# Altium schematic sheet 08_DDR_64.SchDoc(08_DDR_64)
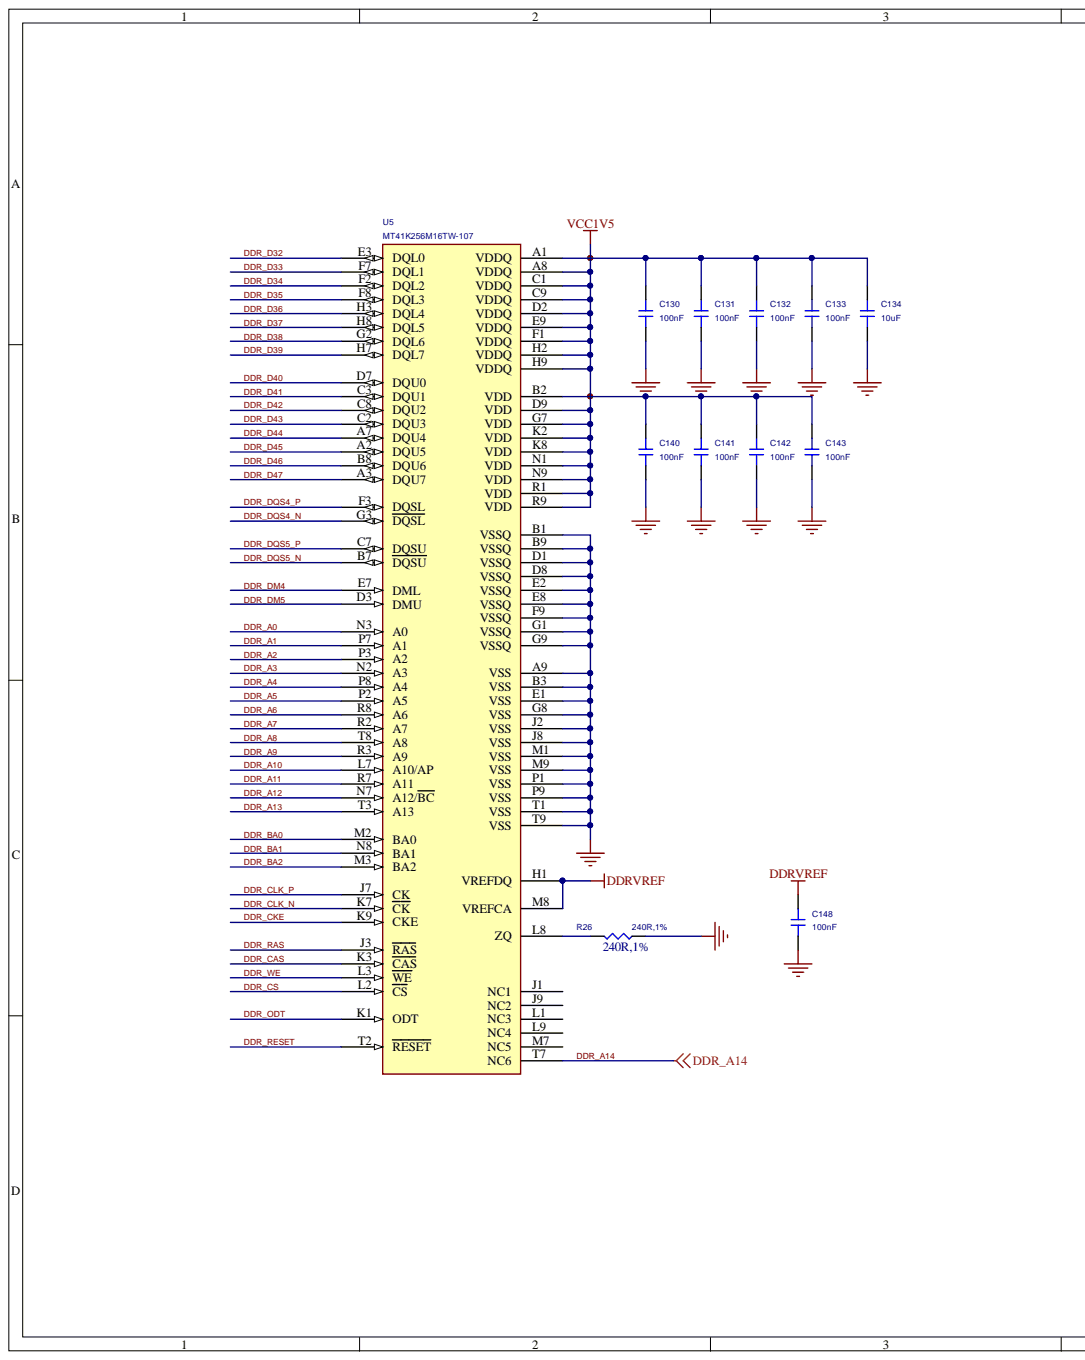
[diagram p0: 08_DDR_64.SchDoc, left]
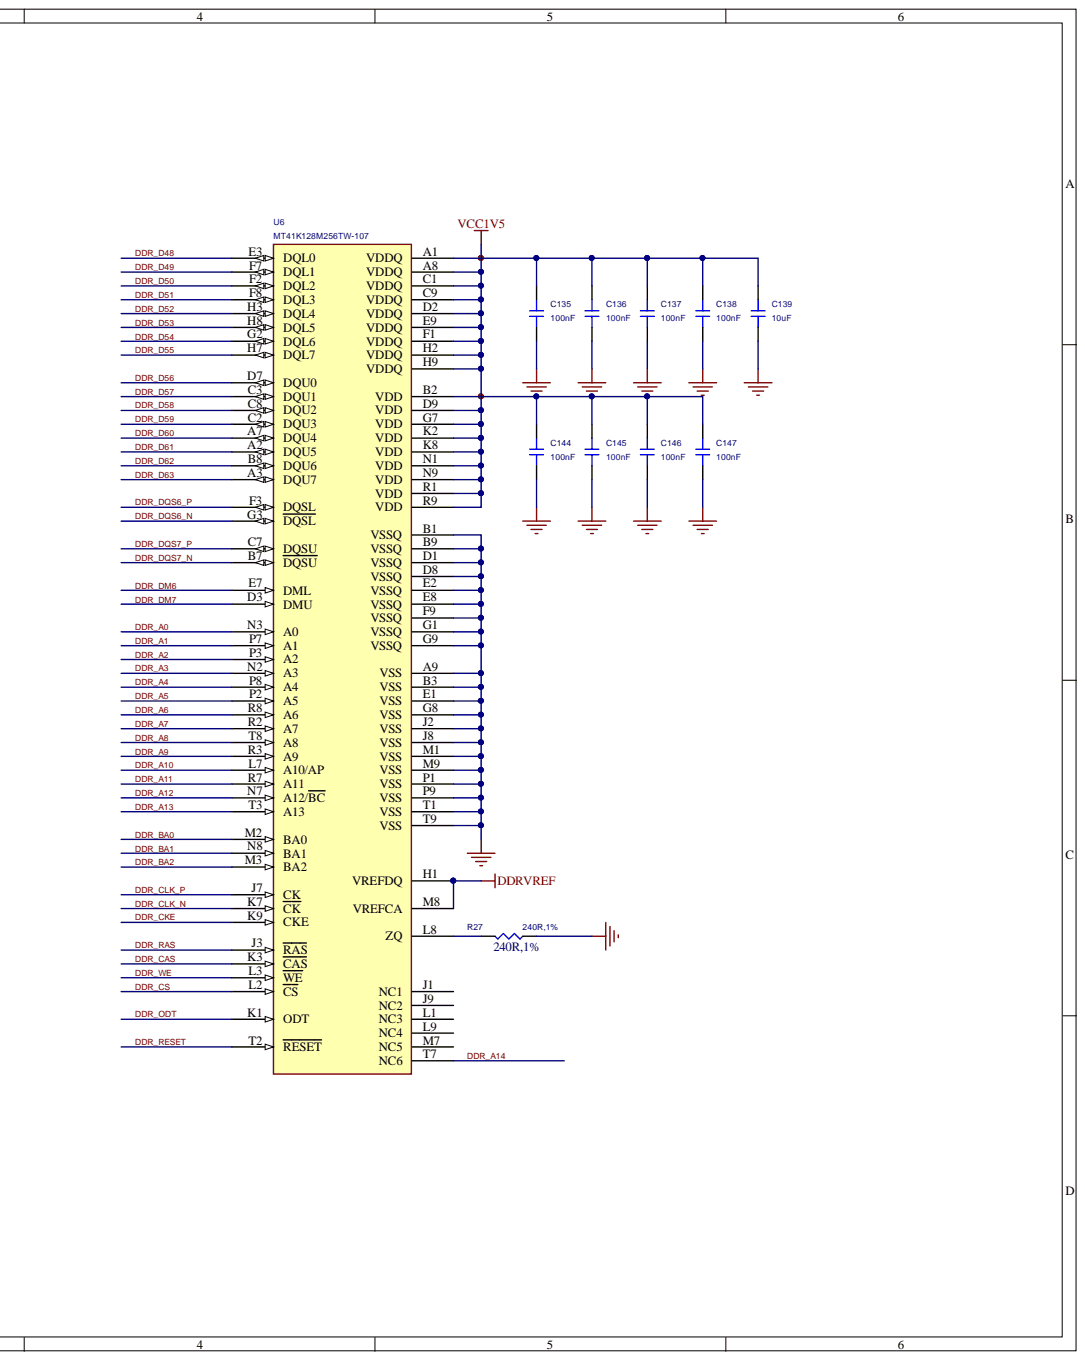
[diagram p1: 08_DDR_64.SchDoc, right]

## Parts
C130  100nF  [C0402]
C131  100nF  [C0402]
C132  100nF  [C0402]
C133  100nF  [C0402]
C134  10uF  [C0402]
C135  100nF  [C0402]
C136  100nF  [C0402]
C137  100nF  [C0402]
C138  100nF  [C0402]
C139  10uF  [C0402]
C140  100nF  [C0402]
C141  100nF  [C0402]
C142  100nF  [C0402]
C143  100nF  [C0402]
C144  100nF  [C0402]
C145  100nF  [C0402]
C146  100nF  [C0402]
C147  100nF  [C0402]
C148  100nF  [C0402]
R26  240R,1%  [R0402]
R27  240R,1%  [R0402]
U5  MT41K256M16TW-107  [BGA96_80P7_5X13_3X1]
U6  MT41K128M256TW-107  [BGA96_80P7_5X13_3X1]

## Nets
DDRVREF: C148.2 U5.H1(VREFDQ) U5.M8(VREFCA) U6.H1(VREFDQ) U6.M8(VREFCA)
GND: C130.1 C131.1 C132.1 C133.1 C134.1 C135.1 C136.1 C137.1 C138.1 C139.1 C140.1 C141.1 C142.1 C143.1 C144.1 C145.1 C146.1 C147.1 C148.1 R26.2 R27.2 U5.A9(VSS) U5.B1(VSSQ) U5.B3(VSS) U5.B9(VSSQ) U5.D1(VSSQ) U5.D8(VSSQ) U5.E1(VSS) U5.E2(VSSQ) U5.E8(VSSQ) U5.F9(VSSQ) U5.G1(VSSQ) U5.G8(VSS) U5.G9(VSSQ) U5.J2(VSS) U5.J8(VSS) U5.M1(VSS) U5.M9(VSS) U5.P1(VSS) U5.P9(VSS) U5.T1(VSS) U5.T9(VSS) U6.A9(VSS) U6.B1(VSSQ) U6.B3(VSS) U6.B9(VSSQ) U6.D1(VSSQ) U6.D8(VSSQ) U6.E1(VSS) U6.E2(VSSQ) U6.E8(VSSQ) U6.F9(VSSQ) U6.G1(VSSQ) U6.G8(VSS) U6.G9(VSSQ) U6.J2(VSS) U6.J8(VSS) U6.M1(VSS) U6.M9(VSS) U6.P1(VSS) U6.P9(VSS) U6.T1(VSS) U6.T9(VSS)
VCC1V5: C130.2 C131.2 C132.2 C133.2 C134.2 C135.2 C136.2 C137.2 C138.2 C139.2 C140.2 C141.2 C142.2 C143.2 C144.2 C145.2 C146.2 C147.2 U5.A1(VDDQ) U5.A8(VDDQ) U5.B2(VDD) U5.C1(VDDQ) U5.C9(VDDQ) U5.D2(VDDQ) U5.D9(VDD) U5.E9(VDDQ) U5.F1(VDDQ) U5.G7(VDD) U5.H2(VDDQ) U5.H9(VDDQ) U5.K2(VDD) U5.K8(VDD) U5.N1(VDD) U5.N9(VDD) U5.R1(VDD) U5.R9(VDD) U6.A1(VDDQ) U6.A8(VDDQ) U6.B2(VDD) U6.C1(VDDQ) U6.C9(VDDQ) U6.D2(VDDQ) U6.D9(VDD) U6.E9(VDDQ) U6.F1(VDDQ) U6.G7(VDD) U6.H2(VDDQ) U6.H9(VDDQ) U6.K2(VDD) U6.K8(VDD) U6.N1(VDD) U6.N9(VDD) U6.R1(VDD) U6.R9(VDD)
DDR_A0: U5.N3(A0) U6.N3(A0)
DDR_A1: U5.P7(A1) U6.P7(A1)
DDR_A2: U5.P3(A2) U6.P3(A2)
DDR_A3: U5.N2(A3) U6.N2(A3)
DDR_A4: U5.P8(A4) U6.P8(A4)
DDR_A5: U5.P2(A5) U6.P2(A5)
DDR_A6: U5.R8(A6) U6.R8(A6)
DDR_A7: U5.R2(A7) U6.R2(A7)
DDR_A8: U5.T8(A8) U6.T8(A8)
DDR_A9: U5.R3(A9) U6.R3(A9)
DDR_A10: U5.L7(A10/AP) U6.L7(A10/AP)
DDR_A11: U5.R7(A11) U6.R7(A11)
DDR_A12: U5.N7(A12/BC) U6.N7(A12/BC)
DDR_A13: U5.T3(A13) U6.T3(A13)
DDR_A14: U5.T7(NC6) U6.T7(NC6)
DDR_BA0: U5.M2(BA0) U6.M2(BA0)
DDR_BA1: U5.N8(BA1) U6.N8(BA1)
DDR_BA2: U5.M3(BA2) U6.M3(BA2)
DDR_CAS: U5.K3(CAS) U6.K3(CAS)
DDR_CKE: U5.K9(CKE) U6.K9(CKE)
DDR_CLK_N: U5.K7(CK) U6.K7(CK)
DDR_CLK_P: U5.J7(CK) U6.J7(CK)
DDR_CS: U5.L2(CS) U6.L2(CS)
DDR_D32: U5.E3(DQL0)
DDR_D33: U5.F7(DQL1)
DDR_D34: U5.F2(DQL2)
DDR_D35: U5.F8(DQL3)
DDR_D36: U5.H3(DQL4)
DDR_D37: U5.H8(DQL5)
DDR_D38: U5.G2(DQL6)
DDR_D39: U5.H7(DQL7)
DDR_D40: U5.D7(DQU0)
DDR_D41: U5.C3(DQU1)
DDR_D42: U5.C8(DQU2)
DDR_D43: U5.C2(DQU3)
DDR_D44: U5.A7(DQU4)
DDR_D45: U5.A2(DQU5)
DDR_D46: U5.B8(DQU6)
DDR_D47: U5.A3(DQU7)
DDR_D48: U6.E3(DQL0)
DDR_D49: U6.F7(DQL1)
DDR_D50: U6.F2(DQL2)
DDR_D51: U6.F8(DQL3)
DDR_D52: U6.H3(DQL4)
DDR_D53: U6.H8(DQL5)
DDR_D54: U6.G2(DQL6)
DDR_D55: U6.H7(DQL7)
DDR_D56: U6.D7(DQU0)
DDR_D57: U6.C3(DQU1)
DDR_D58: U6.C8(DQU2)
DDR_D59: U6.C2(DQU3)
DDR_D60: U6.A7(DQU4)
DDR_D61: U6.A2(DQU5)
DDR_D62: U6.B8(DQU6)
DDR_D63: U6.A3(DQU7)
DDR_DM4: U5.E7(DML)
DDR_DM5: U5.D3(DMU)
DDR_DM6: U6.E7(DML)
DDR_DM7: U6.D3(DMU)
DDR_DQS4_N: U5.G3(DQSL)
DDR_DQS4_P: U5.F3(DQSL)
DDR_DQS5_N: U5.B7(DQSU)
DDR_DQS5_P: U5.C7(DQSU)
DDR_DQS6_N: U6.G3(DQSL)
DDR_DQS6_P: U6.F3(DQSL)
DDR_DQS7_N: U6.B7(DQSU)
DDR_DQS7_P: U6.C7(DQSU)
DDR_ODT: U5.K1(ODT) U6.K1(ODT)
DDR_RAS: U5.J3(RAS) U6.J3(RAS)
DDR_RESET: U5.T2(RESET) U6.T2(RESET)
DDR_WE: U5.L3(WE) U6.L3(WE)
NetR26_1: R26.1 U5.L8(ZQ)
NetR27_1: R27.1 U6.L8(ZQ)

Not connected: U5 J1(NC1) J9(NC2) L1(NC3) L9(NC4) M7(NC5); U6 J1(NC1) J9(NC2) L1(NC3) L9(NC4) M7(NC5)

## Library data of the parts
C130 = CAP1_Dup1: CURRENT: CIMAX; DIST: FLAT; KNEE: CBMAX; MAX_TEMP: CTMAX; PSpiceTemplate: C^@REFDES %1 %2 ?TOLERANCE|C^@REFDES| @VALUE ?IC/IC=@IC/ TC=@TC1,@TC2 ?TOLERANCE|\n.model C^@REFDES CAP C=1 DEV=@TOLERANCE% TC1=@TC1 TC2=@TC2 VC1=@VC1 VC2=@VC2|; REUSE_ANNOTATE: TRUE; REUSE_ID: 73; SLOPE: CSMAX; TC1: 0; VOLTAGE: CMAX; Component Kind: Standard; Simulation: CAP1; TC2: 0; Value: 100nF; VC1: 0; VC2: 0
C131 = CAP1_Dup1: CURRENT: CIMAX; DIST: FLAT; KNEE: CBMAX; MAX_TEMP: CTMAX; PSpiceTemplate: C^@REFDES %1 %2 ?TOLERANCE|C^@REFDES| @VALUE ?IC/IC=@IC/ TC=@TC1,@TC2 ?TOLERANCE|\n.model C^@REFDES CAP C=1 DEV=@TOLERANCE% TC1=@TC1 TC2=@TC2 VC1=@VC1 VC2=@VC2|; REUSE_ANNOTATE: TRUE; REUSE_ID: 79; SLOPE: CSMAX; TC1: 0; VOLTAGE: CMAX; Component Kind: Standard; Simulation: CAP1; TC2: 0; Value: 100nF; VC1: 0; VC2: 0
C132 = CAP1_Dup1: CURRENT: CIMAX; DIST: FLAT; KNEE: CBMAX; MAX_TEMP: CTMAX; PSpiceTemplate: C^@REFDES %1 %2 ?TOLERANCE|C^@REFDES| @VALUE ?IC/IC=@IC/ TC=@TC1,@TC2 ?TOLERANCE|\n.model C^@REFDES CAP C=1 DEV=@TOLERANCE% TC1=@TC1 TC2=@TC2 VC1=@VC1 VC2=@VC2|; REUSE_ANNOTATE: TRUE; REUSE_ID: 65; SLOPE: CSMAX; TC1: 0; VOLTAGE: CMAX; Component Kind: Standard; Simulation: CAP1; TC2: 0; Value: 100nF; VC1: 0; VC2: 0
C133 = CAP1_Dup1: CURRENT: CIMAX; DIST: FLAT; KNEE: CBMAX; MAX_TEMP: CTMAX; PSpiceTemplate: C^@REFDES %1 %2 ?TOLERANCE|C^@REFDES| @VALUE ?IC/IC=@IC/ TC=@TC1,@TC2 ?TOLERANCE|\n.model C^@REFDES CAP C=1 DEV=@TOLERANCE% TC1=@TC1 TC2=@TC2 VC1=@VC1 VC2=@VC2|; REUSE_ANNOTATE: TRUE; REUSE_ID: 63; SLOPE: CSMAX; TC1: 0; VOLTAGE: CMAX; Component Kind: Standard; Simulation: CAP1; TC2: 0; Value: 100nF; VC1: 0; VC2: 0
C134 = CAP1_Dup1: CURRENT: CIMAX; DIST: FLAT; KNEE: CBMAX; MAX_TEMP: CTMAX; PSpiceTemplate: C^@REFDES %1 %2 ?TOLERANCE|C^@REFDES| @VALUE ?IC/IC=@IC/ TC=@TC1,@TC2 ?TOLERANCE|\n.model C^@REFDES CAP C=1 DEV=@TOLERANCE% TC1=@TC1 TC2=@TC2 VC1=@VC1 VC2=@VC2|; REUSE_ANNOTATE: TRUE; REUSE_ID: 76; SLOPE: CSMAX; TC1: 0; VOLTAGE: CMAX; Component Kind: Standard; Simulation: CAP1; TC2: 0; Value: 10uF; VC1: 0; VC2: 0
C135 = CAP1_Dup1: CURRENT: CIMAX; DIST: FLAT; KNEE: CBMAX; MAX_TEMP: CTMAX; PSpiceTemplate: C^@REFDES %1 %2 ?TOLERANCE|C^@REFDES| @VALUE ?IC/IC=@IC/ TC=@TC1,@TC2 ?TOLERANCE|\n.model C^@REFDES CAP C=1 DEV=@TOLERANCE% TC1=@TC1 TC2=@TC2 VC1=@VC1 VC2=@VC2|; REUSE_ANNOTATE: TRUE; REUSE_ID: 74; SLOPE: CSMAX; TC1: 0; VOLTAGE: CMAX; Component Kind: Standard; Simulation: CAP1; TC2: 0; Value: 100nF; VC1: 0; VC2: 0
C136 = CAP1_Dup1: CURRENT: CIMAX; DIST: FLAT; KNEE: CBMAX; MAX_TEMP: CTMAX; PSpiceTemplate: C^@REFDES %1 %2 ?TOLERANCE|C^@REFDES| @VALUE ?IC/IC=@IC/ TC=@TC1,@TC2 ?TOLERANCE|\n.model C^@REFDES CAP C=1 DEV=@TOLERANCE% TC1=@TC1 TC2=@TC2 VC1=@VC1 VC2=@VC2|; REUSE_ANNOTATE: TRUE; REUSE_ID: 81; SLOPE: CSMAX; TC1: 0; VOLTAGE: CMAX; Component Kind: Standard; Simulation: CAP1; TC2: 0; Value: 100nF; VC1: 0; VC2: 0
C137 = CAP1_Dup1: CURRENT: CIMAX; DIST: FLAT; KNEE: CBMAX; MAX_TEMP: CTMAX; PSpiceTemplate: C^@REFDES %1 %2 ?TOLERANCE|C^@REFDES| @VALUE ?IC/IC=@IC/ TC=@TC1,@TC2 ?TOLERANCE|\n.model C^@REFDES CAP C=1 DEV=@TOLERANCE% TC1=@TC1 TC2=@TC2 VC1=@VC1 VC2=@VC2|; REUSE_ANNOTATE: TRUE; REUSE_ID: 62; SLOPE: CSMAX; TC1: 0; VOLTAGE: CMAX; Component Kind: Standard; Simulation: CAP1; TC2: 0; Value: 100nF; VC1: 0; VC2: 0
C138 = CAP1_Dup1: CURRENT: CIMAX; DIST: FLAT; KNEE: CBMAX; MAX_TEMP: CTMAX; PSpiceTemplate: C^@REFDES %1 %2 ?TOLERANCE|C^@REFDES| @VALUE ?IC/IC=@IC/ TC=@TC1,@TC2 ?TOLERANCE|\n.model C^@REFDES CAP C=1 DEV=@TOLERANCE% TC1=@TC1 TC2=@TC2 VC1=@VC1 VC2=@VC2|; REUSE_ANNOTATE: TRUE; REUSE_ID: 70; SLOPE: CSMAX; TC1: 0; VOLTAGE: CMAX; Component Kind: Standard; Simulation: CAP1; TC2: 0; Value: 100nF; VC1: 0; VC2: 0
C139 = CAP1_Dup1: CURRENT: CIMAX; DIST: FLAT; KNEE: CBMAX; MAX_TEMP: CTMAX; PSpiceTemplate: C^@REFDES %1 %2 ?TOLERANCE|C^@REFDES| @VALUE ?IC/IC=@IC/ TC=@TC1,@TC2 ?TOLERANCE|\n.model C^@REFDES CAP C=1 DEV=@TOLERANCE% TC1=@TC1 TC2=@TC2 VC1=@VC1 VC2=@VC2|; REUSE_ANNOTATE: TRUE; REUSE_ID: 75; SLOPE: CSMAX; TC1: 0; VOLTAGE: CMAX; Component Kind: Standard; Simulation: CAP1; TC2: 0; Value: 10uF; VC1: 0; VC2: 0
C140 C148 = CAP1_Dup1: CURRENT: CIMAX; DIST: FLAT; KNEE: CBMAX; MAX_TEMP: CTMAX; PSpiceTemplate: C^@REFDES %1 %2 ?TOLERANCE|C^@REFDES| @VALUE ?IC/IC=@IC/ TC=@TC1,@TC2 ?TOLERANCE|\n.model C^@REFDES CAP C=1 DEV=@TOLERANCE% TC1=@TC1 TC2=@TC2 VC1=@VC1 VC2=@VC2|; REUSE_ANNOTATE: TRUE; REUSE_ID: 77; SLOPE: CSMAX; TC1: 0; VOLTAGE: CMAX; Component Kind: Standard; Simulation: CAP1; TC2: 0; Value: 100nF; VC1: 0; VC2: 0
C141 = CAP1_Dup1: CURRENT: CIMAX; DIST: FLAT; KNEE: CBMAX; MAX_TEMP: CTMAX; PSpiceTemplate: C^@REFDES %1 %2 ?TOLERANCE|C^@REFDES| @VALUE ?IC/IC=@IC/ TC=@TC1,@TC2 ?TOLERANCE|\n.model C^@REFDES CAP C=1 DEV=@TOLERANCE% TC1=@TC1 TC2=@TC2 VC1=@VC1 VC2=@VC2|; REUSE_ANNOTATE: TRUE; REUSE_ID: 64; SLOPE: CSMAX; TC1: 0; VOLTAGE: CMAX; Component Kind: Standard; Simulation: CAP1; TC2: 0; Value: 100nF; VC1: 0; VC2: 0
C142 = CAP1_Dup1: CURRENT: CIMAX; DIST: FLAT; KNEE: CBMAX; MAX_TEMP: CTMAX; PSpiceTemplate: C^@REFDES %1 %2 ?TOLERANCE|C^@REFDES| @VALUE ?IC/IC=@IC/ TC=@TC1,@TC2 ?TOLERANCE|\n.model C^@REFDES CAP C=1 DEV=@TOLERANCE% TC1=@TC1 TC2=@TC2 VC1=@VC1 VC2=@VC2|; REUSE_ANNOTATE: TRUE; REUSE_ID: 83; SLOPE: CSMAX; TC1: 0; VOLTAGE: CMAX; Component Kind: Standard; Simulation: CAP1; TC2: 0; Value: 100nF; VC1: 0; VC2: 0
C143 = CAP1_Dup1: CURRENT: CIMAX; DIST: FLAT; KNEE: CBMAX; MAX_TEMP: CTMAX; PSpiceTemplate: C^@REFDES %1 %2 ?TOLERANCE|C^@REFDES| @VALUE ?IC/IC=@IC/ TC=@TC1,@TC2 ?TOLERANCE|\n.model C^@REFDES CAP C=1 DEV=@TOLERANCE% TC1=@TC1 TC2=@TC2 VC1=@VC1 VC2=@VC2|; REUSE_ANNOTATE: TRUE; REUSE_ID: 72; SLOPE: CSMAX; TC1: 0; VOLTAGE: CMAX; Component Kind: Standard; Simulation: CAP1; TC2: 0; Value: 100nF; VC1: 0; VC2: 0
C144 = CAP1_Dup1: CURRENT: CIMAX; DIST: FLAT; KNEE: CBMAX; MAX_TEMP: CTMAX; PSpiceTemplate: C^@REFDES %1 %2 ?TOLERANCE|C^@REFDES| @VALUE ?IC/IC=@IC/ TC=@TC1,@TC2 ?TOLERANCE|\n.model C^@REFDES CAP C=1 DEV=@TOLERANCE% TC1=@TC1 TC2=@TC2 VC1=@VC1 VC2=@VC2|; REUSE_ANNOTATE: TRUE; REUSE_ID: 68; SLOPE: CSMAX; TC1: 0; VOLTAGE: CMAX; Component Kind: Standard; Simulation: CAP1; TC2: 0; Value: 100nF; VC1: 0; VC2: 0
C145 = CAP1_Dup1: CURRENT: CIMAX; DIST: FLAT; KNEE: CBMAX; MAX_TEMP: CTMAX; PSpiceTemplate: C^@REFDES %1 %2 ?TOLERANCE|C^@REFDES| @VALUE ?IC/IC=@IC/ TC=@TC1,@TC2 ?TOLERANCE|\n.model C^@REFDES CAP C=1 DEV=@TOLERANCE% TC1=@TC1 TC2=@TC2 VC1=@VC1 VC2=@VC2|; REUSE_ANNOTATE: TRUE; REUSE_ID: 66; SLOPE: CSMAX; TC1: 0; VOLTAGE: CMAX; Component Kind: Standard; Simulation: CAP1; TC2: 0; Value: 100nF; VC1: 0; VC2: 0
C146 = CAP1_Dup1: CURRENT: CIMAX; DIST: FLAT; KNEE: CBMAX; MAX_TEMP: CTMAX; PSpiceTemplate: C^@REFDES %1 %2 ?TOLERANCE|C^@REFDES| @VALUE ?IC/IC=@IC/ TC=@TC1,@TC2 ?TOLERANCE|\n.model C^@REFDES CAP C=1 DEV=@TOLERANCE% TC1=@TC1 TC2=@TC2 VC1=@VC1 VC2=@VC2|; REUSE_ANNOTATE: TRUE; REUSE_ID: 78; SLOPE: CSMAX; TC1: 0; VOLTAGE: CMAX; Component Kind: Standard; Simulation: CAP1; TC2: 0; Value: 100nF; VC1: 0; VC2: 0
C147 = CAP1_Dup1: CURRENT: CIMAX; DIST: FLAT; KNEE: CBMAX; MAX_TEMP: CTMAX; PSpiceTemplate: C^@REFDES %1 %2 ?TOLERANCE|C^@REFDES| @VALUE ?IC/IC=@IC/ TC=@TC1,@TC2 ?TOLERANCE|\n.model C^@REFDES CAP C=1 DEV=@TOLERANCE% TC1=@TC1 TC2=@TC2 VC1=@VC1 VC2=@VC2|; REUSE_ANNOTATE: TRUE; REUSE_ID: 67; SLOPE: CSMAX; TC1: 0; VOLTAGE: CMAX; Component Kind: Standard; Simulation: CAP1; TC2: 0; Value: 100nF; VC1: 0; VC2: 0
R26 = RES1: DIST: FLAT; MAX_TEMP: RTMAX; POWER: RMAX; PSpiceTemplate: R^@REFDES %1 %2 ?TOLERANCE|R^@REFDES| @VALUE TC=@TC1,@TC2 ?TOLERANCE|\n.model R^@REFDES RES R=1 DEV=@TOLERANCE% TC1=@TC1 TC2=@TC2|; REUSE_ANNOTATE: TRUE; REUSE_ID: 80; SLOPE: RSMAX; TC1: 0; VOLTAGE: RVMAX; Component Kind: Standard; Simulation: RES1; TC2: 0; Value: 240R,1%
R27 = RES1: DIST: FLAT; MAX_TEMP: RTMAX; POWER: RMAX; PSpiceTemplate: R^@REFDES %1 %2 ?TOLERANCE|R^@REFDES| @VALUE TC=@TC1,@TC2 ?TOLERANCE|\n.model R^@REFDES RES R=1 DEV=@TOLERANCE% TC1=@TC1 TC2=@TC2|; REUSE_ANNOTATE: TRUE; REUSE_ID: 71; SLOPE: RSMAX; TC1: 0; VOLTAGE: RVMAX; Component Kind: Standard; Simulation: RES1; TC2: 0; Value: 240R,1%
U5 = K4B2G1646C-HCH9: REUSE_ANNOTATE: TRUE; REUSE_ID: 69; Component Kind: Standard
U6 = K4B2G1646C-HCH9: REUSE_ANNOTATE: TRUE; REUSE_ID: 82; Component Kind: Standard
